# Altium schematic sheet HF_AMP.SchDoc
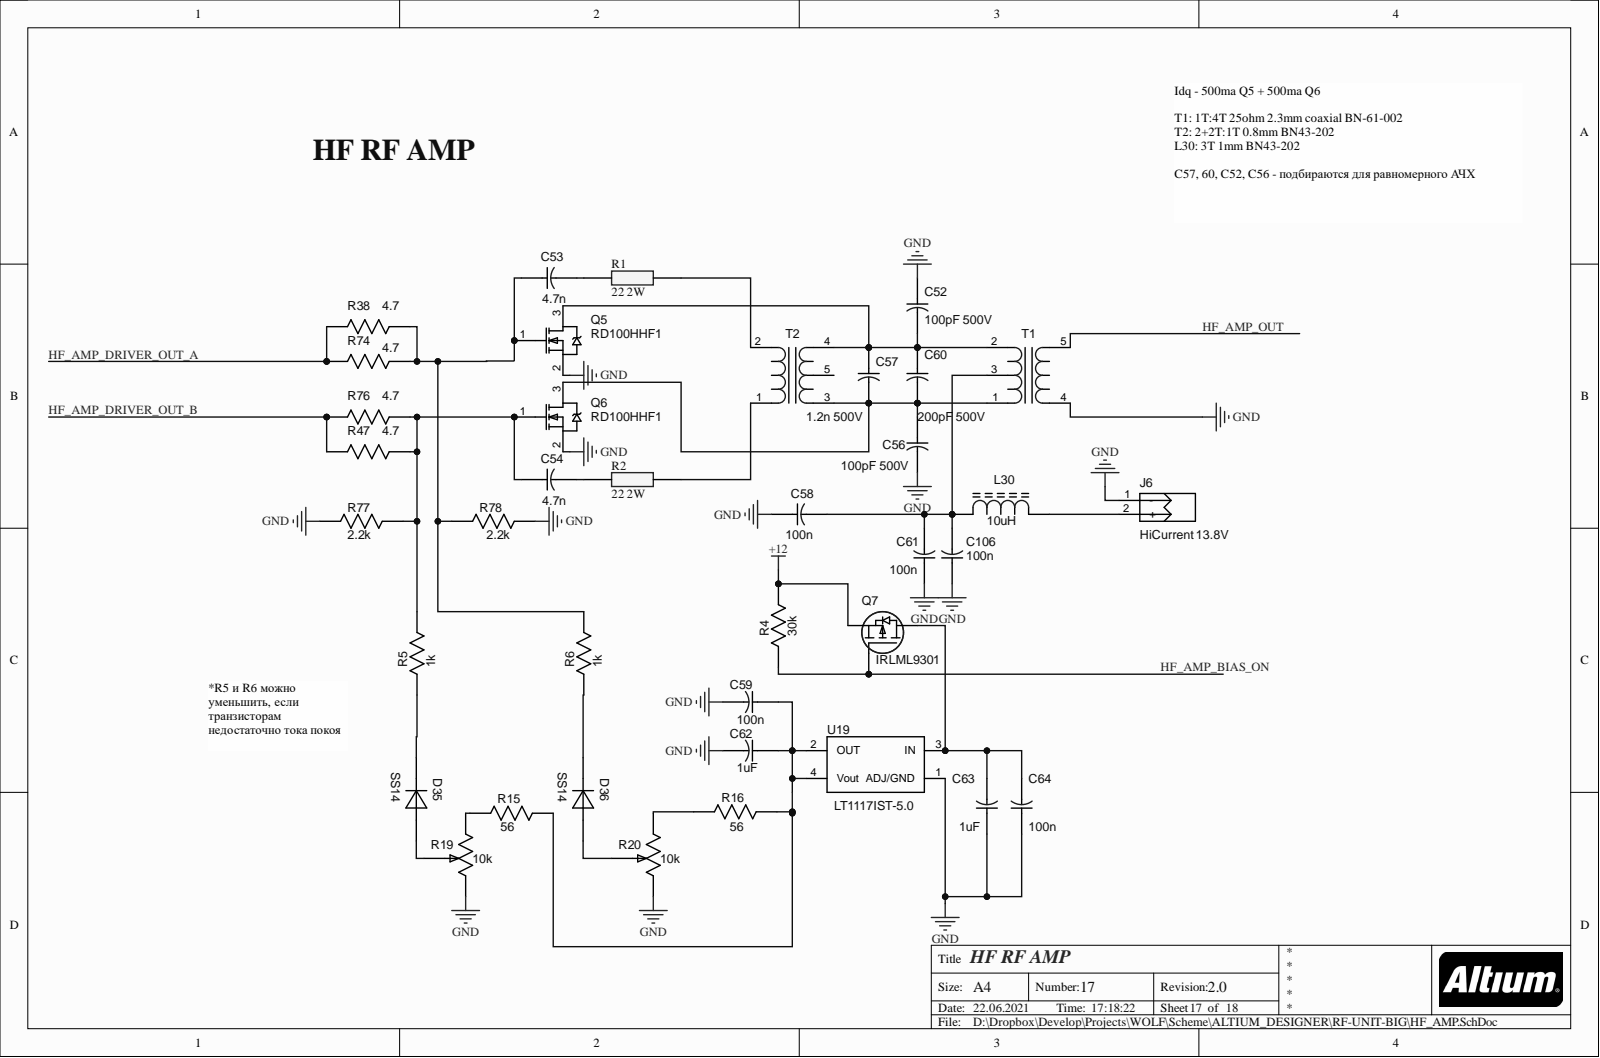
[diagram p0: HF_AMP.SchDoc]

## Parts
C52  100pF 500V  [CAP_1206]
C53  4.7n  [CAP_1206]
C54  4.7n  [CAP_1206]
C56  100pF 500V  [CAP_1206]
C57  1.2n 500V  [CAP_1206]
C58  100n  [CAP_1206]
C59  100n  [CAP_0805]
C60  200pF 500V  [CAP_1206]
C61  100n  [CAP_1206]
C62  1uF  [CAP_0805]
C63  1uF  [CAP_0805]
C64  100n  [CAP_0805]
C106  100n  [CAP_1206]
D35  SS14  [SS14]
D36  SS14  [SS14]
J6  HiCurrent 13.8V  [1986717-2]
L30  10uH  [IND_FERRITE_CORE]
Q5  RD100HHF1  [RD100_V2]
Q6  RD100HHF1  [RD100_V2]
Q7  IRLML9301  [SOT23]
R1  22 2W  [RES-2W]
R2  22 2W  [RES-2W]
R4  30k  [RES_0805]
R5  1k  [RES_0805]
R6  1k  [RES_0805]
R15  56  [RES_0805]
R16  56  [RES_0805]
R19  value 10k  [3296W-1]  (hidden comment: ADJ-10k)
R20  value 10k  [3296W-1]  (hidden comment: ADJ-10k)
R38  4.7  [RES_1206]
R47  4.7  [RES_1206]
R74  4.7  [RES_1206]
R76  4.7  [RES_1206]
R77  2.2k  [RES_0805]
R78  2.2k  [RES_0805]
T1  TRANSF_HF2  [TRANSF_HF2-3]  (value not drawn)
T2  TRANSF_HF1  [TRANSF_HF1]  (value not drawn)
U19  LT1117IST-5.0  [LT1117IST-ADJ]

## Nets
+12: Q7.2 R4.2
GND: C52.1 C56.2 C58.1 C59.2 C61.1 C62.2 C63.1 C64.1 C106.1 J6.1 Q5.2 Q6.2 R19.1 R20.1 R77.1 R78.2 T1.4 U19.1(ADJ/GND)
HF_AMP_BIAS_ON: Q7.1 R4.1
HF_AMP_DRIVER_OUT_A: R38.1 R74.1
HF_AMP_DRIVER_OUT_B: R47.1 R76.1
HF_AMP_OUT: T1.5
NetC52_2: C52.2 C57.1 C60.1 Q5.3 T1.2 T2.4
NetC53_1: C53.1 Q5.1 R6.2 R38.2 R74.2 R78.1
NetC53_2: C53.2 R1.1
NetC54_1: C54.1 Q6.1 R5.2 R47.2 R76.2 R77.2
NetC54_2: C54.2 R2.1
NetC56_1: C56.1 C57.2 C60.2 Q6.3 T1.1 T2.3
NetC58_2: C58.2 C61.2 C106.2 L30.1 T1.3
NetC59_1: C59.1 C62.1 R15.2 R16.2 U19.2(OUT) U19.4(Vout)
NetC63_2: C63.2 C64.2 Q7.3 U19.3(IN)
NetD35_1: D35.1 R5.1
NetD35_2: D35.2 R19.2
NetD36_1: D36.1 R6.1
NetD36_2: D36.2 R20.2
NetJ6_2: J6.2 L30.2
NetR1_2: R1.2 T2.2
NetR2_2: R2.2 T2.1
NetR15_1: R15.1 R19.3
NetR16_1: R16.1 R20.3

Not connected: T2 5

## Library data of the parts
C52-C54 C56-C58 C60 C61 C106 = CAP_1206: Component Kind: Standard; Description: CAP_1206
C59 C62-C64 = CAP_0805: Component Kind: Standard; Description: CAP_0805
  C59 C64: Value: 100n
  C62 C63: Value: 1uF
J6 = 1986717-2: JX2; Component Kind: Standard
L30 = IND_FERRITE_CORE: Inductor; Component Kind: Standard; Value: 10uH
Q7 = MOSFET_ENH_PD: MOSFET; Component Kind: Standard; Value: IRLML9301
R1 R2 = CFR200J100R: Manufacturer_Name: TE Connectivity; Arrow Part Number: CFR200J100R; Arrow Price/Stock: https://www.arrow.com/en/products/cfr200j100r/te-connectivity; Component Kind: Standard; Datasheet Link: http://www.te.com/commerce/DocumentDelivery/DDEController?Action=showdoc&DocId=Data+Sheet%7F1773195%7FE%7Fpdf%7FEnglish%7FENG_DS_1773195_E.pdf%7F1623929-2; Description: CFR200J100R; Manufacturer_Part_Number: CFR200J100R; Mouser Part Number: 279-CFR200J100R; Mouser Price/Stock: https://www.mouser.com/Search/Refine.aspx?Keyword=279-CFR200J100R; Library Name: RF-UNIT-BIG.SchLib
R4-R6 R15 R16 R77 R78 = RES_0805: Component Kind: Standard; Description: RES_0805
  R4: Value: 30k
R19 R20 = 306J: 3296W-1-103LF; Component Kind: Standard
R38 R47 R74 R76 = RES_1206: Component Kind: Standard; Description: RES_1206
T1 = TRANSF_RF2: Component Kind: Standard; Description: TRANSF_HF2; Library Name: RF-UNIT-BIG.SchLib
T2 = TRANSF_RF1: Component Kind: Standard; Description: TRANSF_HF1; Library Name: RF-UNIT-BIG.SchLib
U19 = LT1117IST-ADJ: Component Kind: Standard; Description: LT1117IST-5.0
D35 D36 = SS14: Component Kind: Standard; Description: SS14; Value: SS14
Q5 Q6 = RD100HHF1: Component Kind: Standard; Description: RD100HHF1; Value: RD100HHF1; Library Name: RF-UNIT-BIG.SchLib
